# Altium schematic sheet DAP RP2040-Zero.SchDoc("DAP RP2040-Zero")
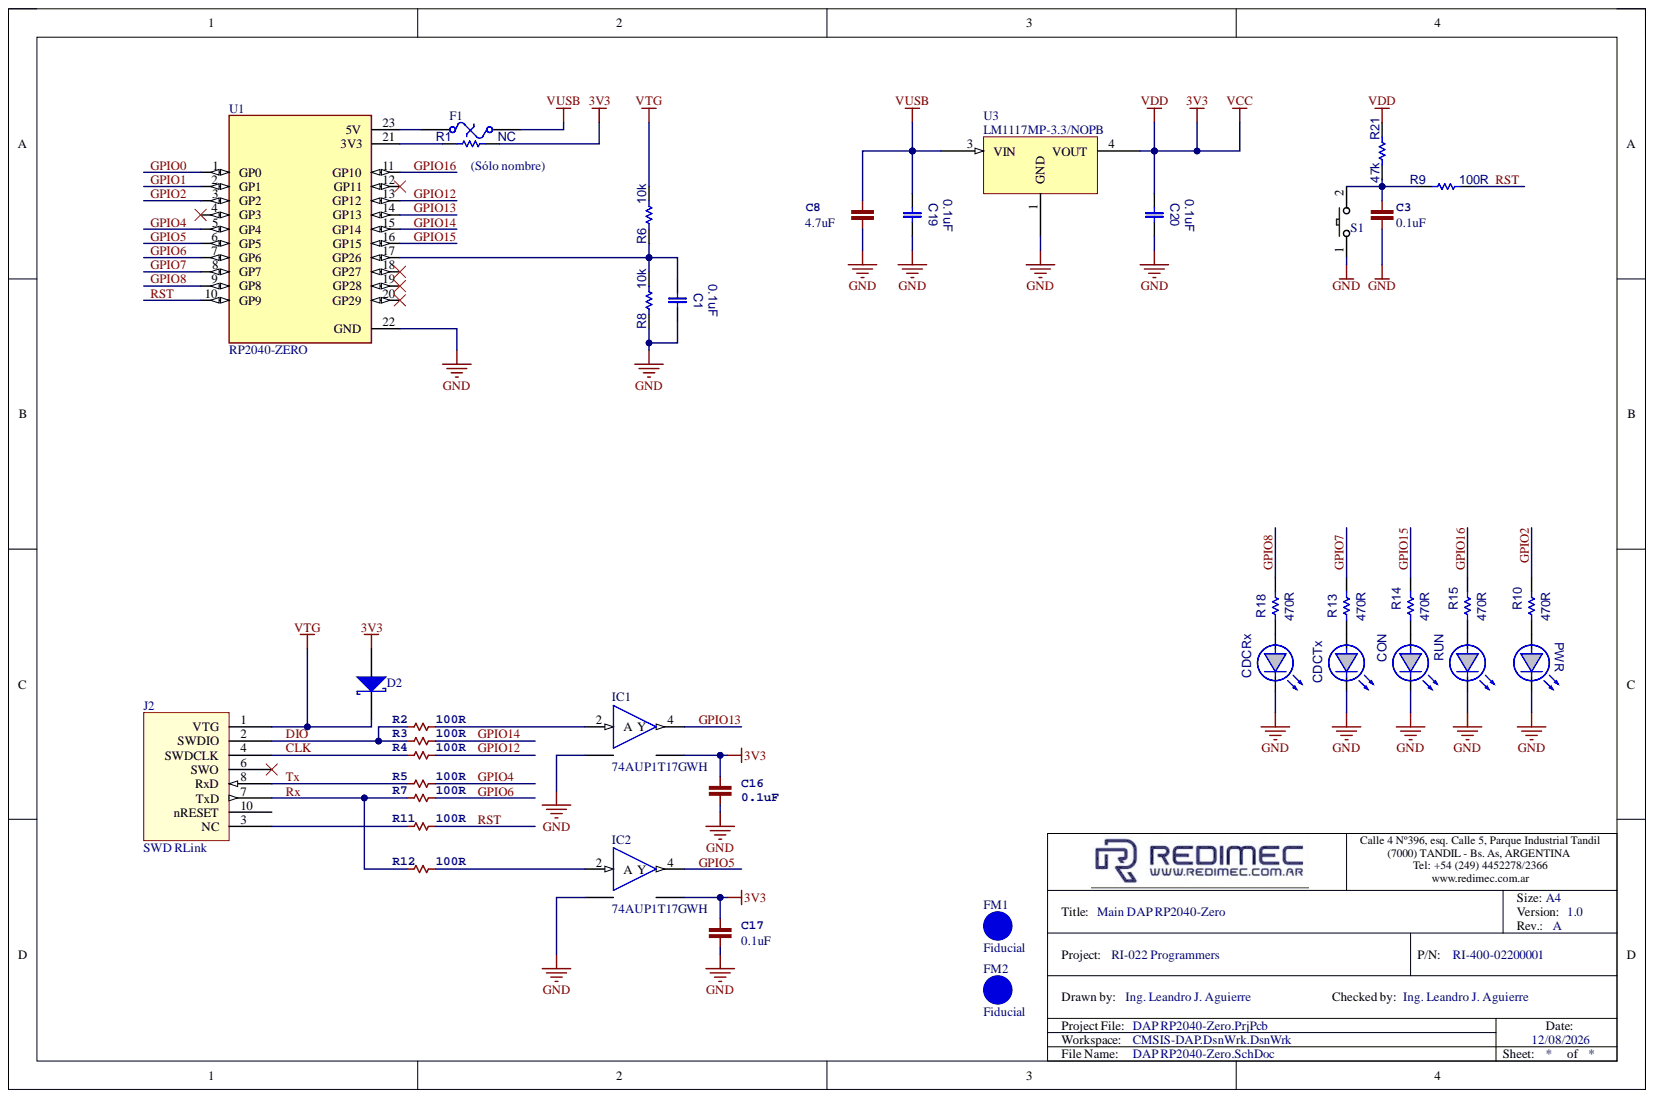
[diagram p0: DAP RP2040-Zero.SchDoc]

## Parts
C1  0.1uF  [0603c]
C3  0.1uF  [0603c]
C8  4.7uF  [0805]
C16  0.1uF  [0603C]
C17  0.1uF  [0603r]
C19  0.1uF  [0603c]
C20  0.1uF  [0603c]
CDCRx  LED  [Led 0603 Red]  (value not drawn)
CDCTx  LED  [Led 0603 Red]  (value not drawn)
CON  LED  [Led 0603 Yellow]  (value not drawn)
D2  D Schottky  [SOD23]  (value not drawn)
F1  Fuse Thermal  [0805r]  (value not drawn)
FM1  Fiducial  [R-2R]  (no BOM)
FM2  Fiducial  [R-2R]  (no BOM)
IC1  74AUP1T17GWH  [SOT65P212X110-5N]
IC2  74AUP1T17GWH  [SOT65P212X110-5N]
J2  SWD RLink  [_HDR2X5 (Borde PCB) - Macho]
PWR  LED  [Led 0603 Red]  (value not drawn)
R1  NC  [0603r]
R2  100R  [0603r]
R3  100R  [0603r]
R4  100R  [0603r]
R5  100R  [0603r]
R6  10k  [0603r]
R7  100R  [0603r]
R8  10k  [0603r]
R9  100R  [0603r]
R10  470R  [0603R]
R11  100R  [0603r]
R12  100R  [0603r]
R13  470R  [0603r]
R14  470R  [0603R]
R15  470R  [0603R]
R18  470R  [0603r]
R21  47k  [0603r]
RUN  LED  [Led 0603 Green]  (value not drawn)
S1  PB  [TD-85XU]  (value not drawn)
U1  RP2040-ZERO  [MODULE_RP2040-ZERO]
U3  LM1117MP-3.3/NOPB  [SOT223-4M]

## Nets
3V3: C16.1 C17.1 C20.1 D2.1 IC1.5 IC2.5 R1.1 R21.2 U3.4
GND: C1.2 C3.2 C8.2 C16.2 C17.2 C19.2 C20.2 CDCRx.2 CDCTx.2 CON.2 IC1.3 IC2.3 J2.5 J2.9 PWR.2 R8.2 RUN.2 S1.1 U1.22(GND) U3.1
VTG: D2.2 J2.1(VTG) R6.1
VUSB: C8.1 C19.1 F1.2 U3.3
CLK: J2.4(SWDCLK) R4.1
DIO: J2.2(SWDIO) R2.1 R3.1
GPIO0: U1.1(GP0)
GPIO1: U1.2(GP1)
GPIO2: R10.2 U1.3(GP2)
GPIO4: R5.2 U1.5(GP4)
GPIO5: IC2.4(Y) U1.6(GP5)
GPIO6: R7.2 U1.7(GP6)
GPIO7: R13.2 U1.8(GP7)
GPIO8: R18.2 U1.9(GP8)
GPIO12: R4.2 U1.13(GP12)
GPIO13: IC1.4(Y) U1.14(GP13)
GPIO14: R3.2 U1.15(GP14)
GPIO15: R14.1 U1.16(GP15)
GPIO16: R15.2 U1.11(GP10)
RST: R9.1 R11.2 U1.10(GP9)
Rx: J2.7(TxD) R7.1 R12.1
Tx: J2.8(RxD) R5.1
NetC1_1: C1.1 R6.2 R8.1 U1.17(GP26)
NetC3_1: C3.1 R9.2 R21.1 S1.2
NetCDCRx_1: CDCRx.1 R18.1
NetCDCTx_1: CDCTx.1 R13.1
NetCON_1: CON.1 R14.2
NetF1_1: F1.1 U1.23(5V)
NetIC1_2: IC1.2(A) R2.2
NetIC2_2: IC2.2(A) R12.2
NetJ2_3: J2.3(NC) R11.1
NetPWR_1: PWR.1 R10.1
NetR1_2: R1.2 U1.21(3V3)
NetR15_1: R15.1 RUN.1

Not connected: J2 6(SWO) 10(nRESET); U1 4(GP3) 12(GP11) 18(GP27) 19(GP28) 20(GP29)

## Library data of the parts
C1 C19 C20 = Capacitor Ceramic: Component Kind: Standard; Description: Capacitor Ceramic; Library Name: altium_lib_1.IntLib; Value: 0.1uF
C3 C8 C16 C17 = CAP-0603: Capacitor; Component Kind: Standard; Library Name: X893.IntLib
  C3 C16 C17: Value: 0.1uF
  C8: Value: 4.7uF
D2 = D Schottky: Schottky Diode; Code_JEDEC: DO-214-AA; Component Kind: Standard; LatestRevisionDate: 17-Jul-2002; LatestRevisionNote: Re-released for DXP Platform.; Library Name: Miscellaneous Devices.IntLib; PackageReference: SMB; Published: 8-Jun-2000; Signal Integrity: D Schottky; Simulation: SKYDIODE; Publisher: Altium Limited
F1 = Fuse Thermal: Thermal Fuse; Component Kind: Standard; LatestRevisionDate: 17-Jul-2002; LatestRevisionNote: Re-released for DXP Platform.; Library Name: Miscellaneous Devices.IntLib; PackageDescription: Thru-Hole; 2 Leads; PackageReference: PIN-W2/E2.8; Published: 24-Mar-1999; Publisher: Altium Limited
FM1 FM2 = R-2R (Fiducial): P/N: R-2R (Fiducial Mark); Library Name: Specific Applications.SchLib
IC1 IC2 = 74AUP1T17GWH: Integrated Circuit; Manufacturer_Name: Nexperia; Arrow Part Number: 74AUP1T17GWH; Arrow Price/Stock: https://www.arrow.com/en/products/74aup1t17gwh/nexperia?region=nac; Component Kind: Standard; Datasheet Link: https://assets.nexperia.com/documents/data-sheet/74AUP1T17.pdf; Height: 1.1mm; Library Name: Integrados.SchLib; Mouser Part Number: 771-74AUP1T17GWH; Mouser Price/Stock: https://www.mouser.co.uk/ProductDetail/Nexperia/74AUP1T17GWH?qs=W0yvOO0ixfEkWoP8%252BUBOfA%3D%3D; P/N: 74AUP1T17GWH
R1 R6 R8-R10 R13-R15 R18 R21 = Resistor: Component Kind: Standard; Description: Resistor; Library Name: altium_lib_1.IntLib
  R1: Value: NC
  R6 R8: Value: 10k
  R9: Value: 100R
  R10 R13-R15 R18: Value: 470R
  R21: Value: 47k
R2-R5 R7 R11 R12 = RESISTOR-0603: Value: 1k; Component Kind: Standard; Library Name: X893.IntLib
S1 = Push Button SPST: Value: TD-85XU; Component Kind: Standard; Library Name: altium_lib_1.IntLib
U1 = RP2040-ZERO: Low-Cost, High-Performance Pico-Like MCU Board Based On Raspberry Pi Microcontroller RP2040; MF: Waveshare Electronics; Check_prices: https://www.snapeda.com/parts/RP2040-ZERO/Waveshare+Electronics/view-part/?ref=eda; Component Kind: Standard; Library Name: RP2040-ZERO.SchLib; MP: RP2040-ZERO; Package: Package; SnapEDA_Link: https://www.snapeda.com/parts/RP2040-ZERO/Waveshare+Electronics/view-part/?ref=snap
U3 = LM1117-XX: IC REG LDO 3.3V 0.8A SOT223; Manufacturer: Texas Instruments; Manufacturer Part Number: LM1117IMP-3.3/NOPB; Accuracy: 1%; Current Rating: 800A; Dropout Voltage: 1.2V; Max Input Voltage: 15V; Max Output Current: 800mA; Max Supply Voltage: 10V; Min Input Voltage: 2.6V; Min Supply Voltage: 4.75V; Number of Outputs: 1; Output Type: Fixed; Polarity: Positive; Quiescent Current: 15mA; Case/Package: SOT-223; Category: Voltage Regulators - Linear; Component Kind: Standard; ComponentLink1Description: https://datasheet.ciiva.com/27051/1281318-27051810.pdf?src-supplier=Jameco; ComponentLink1URL: https://datasheet.ciiva.com/27051/1281318-27051810.pdf?src-supplier=Jameco; ComponentLink2Description: https://datasheet.ciiva.com/8042/1670636-8042261.pdf?src-supplier=Element14; ComponentLink2URL: https://datasheet.ciiva.com/8042/1670636-8042261.pdf?src-supplier=Element14; ComponentLink3Description: https://datasheet.ciiva.com/1570/1849796-1570171.pdf?src-supplier=Farnell; ComponentLink3URL: https://datasheet.ciiva.com/1570/1849796-1570171.pdf?src-supplier=Farnell; ComponentLink4Description: https://datasheet.ciiva.com/14149/lm1117-eng-tds-14149980.pdf?src-supplier=Distrelec; ComponentLink4URL: https://datasheet.ciiva.com/14149/lm1117-eng-tds-14149980.pdf?src-supplier=Distrelec; ComponentLink5Description: https://datasheet.ciiva.com/46386/1882372-46386042.pdf?src-supplier=Element14; ComponentLink5URL: https://datasheet.ciiva.com/46386/1882372-46386042.pdf?src-supplier=Element14; ComponentLink6Description: https://datasheet.ciiva.com/3223/lm1117-n-3223515.pdf?src-supplier=Avnet; ComponentLink6URL: https://datasheet.ciiva.com/3223/lm1117-n-3223515.pdf?src-supplier=Avnet; ComponentLink7Description: https://datasheet.ciiva.com/6580/lm1117-n-6580030.pdf?src-supplier=Digi-Key; ComponentLink7URL: https://datasheet.ciiva.com/6580/lm1117-n-6580030.pdf?src-supplier=Digi-Key; ComponentLink8Description: https://datasheet.ciiva.com/6580/lm1117-n-6580026.pdf?src-supplier=Digi-Key; ComponentLink8URL: https://datasheet.ciiva.com/6580/lm1117-n-6580026.pdf?src-supplier=Digi-Key; Height: 1.6mm; Lead Free: Lead Free; Length: 6.5mm; Library Name: Integrados.SchLib; Max Operating Temperature: 125°C; Min Current Limit: 800mA; Min Operating Temperature: -40°C; Mount: Surface Mount; Nominal Output Voltage: 3.3V; Number of Pins: 4; Number of Regulators: 1; Output Current: 800mA; Output Voltage: 3.3V; Output Voltage Accuracy: 1%; P/N: LM1117MP-3.3/NOPB; Package Quantity: 1000; Packaging: Tape and Reel; Pricing 1: 1=1.41000, 10=1.27000, 25=1.20000, 50=1.20000, 100=0.98500, 250=0.92100, 500=0.81400, 1000=0.64200, 10000=0.64200 (USD); Radiation Hardening: No; REACH SVHC: No SVHC; RoHS: Yes; RoHS Compliant: Yes; Supplier 1: Mouser; Supplier Part Number 1: 926-LM1117IMP3.3NOPB; Termination: SMD/SMT; Value: LM1117MP-3.3/NOPB; Width: 3.56mm
CDCRx CDCTx CON PWR RUN = LED: Component Kind: Standard; Description: LED; Library Name: altium_lib_1.IntLib
J2 = SWD RLink: Component Kind: Standard; Library Name: Conectores.SchLib
